# Altium schematic sheet 自定义键盘.SchDoc
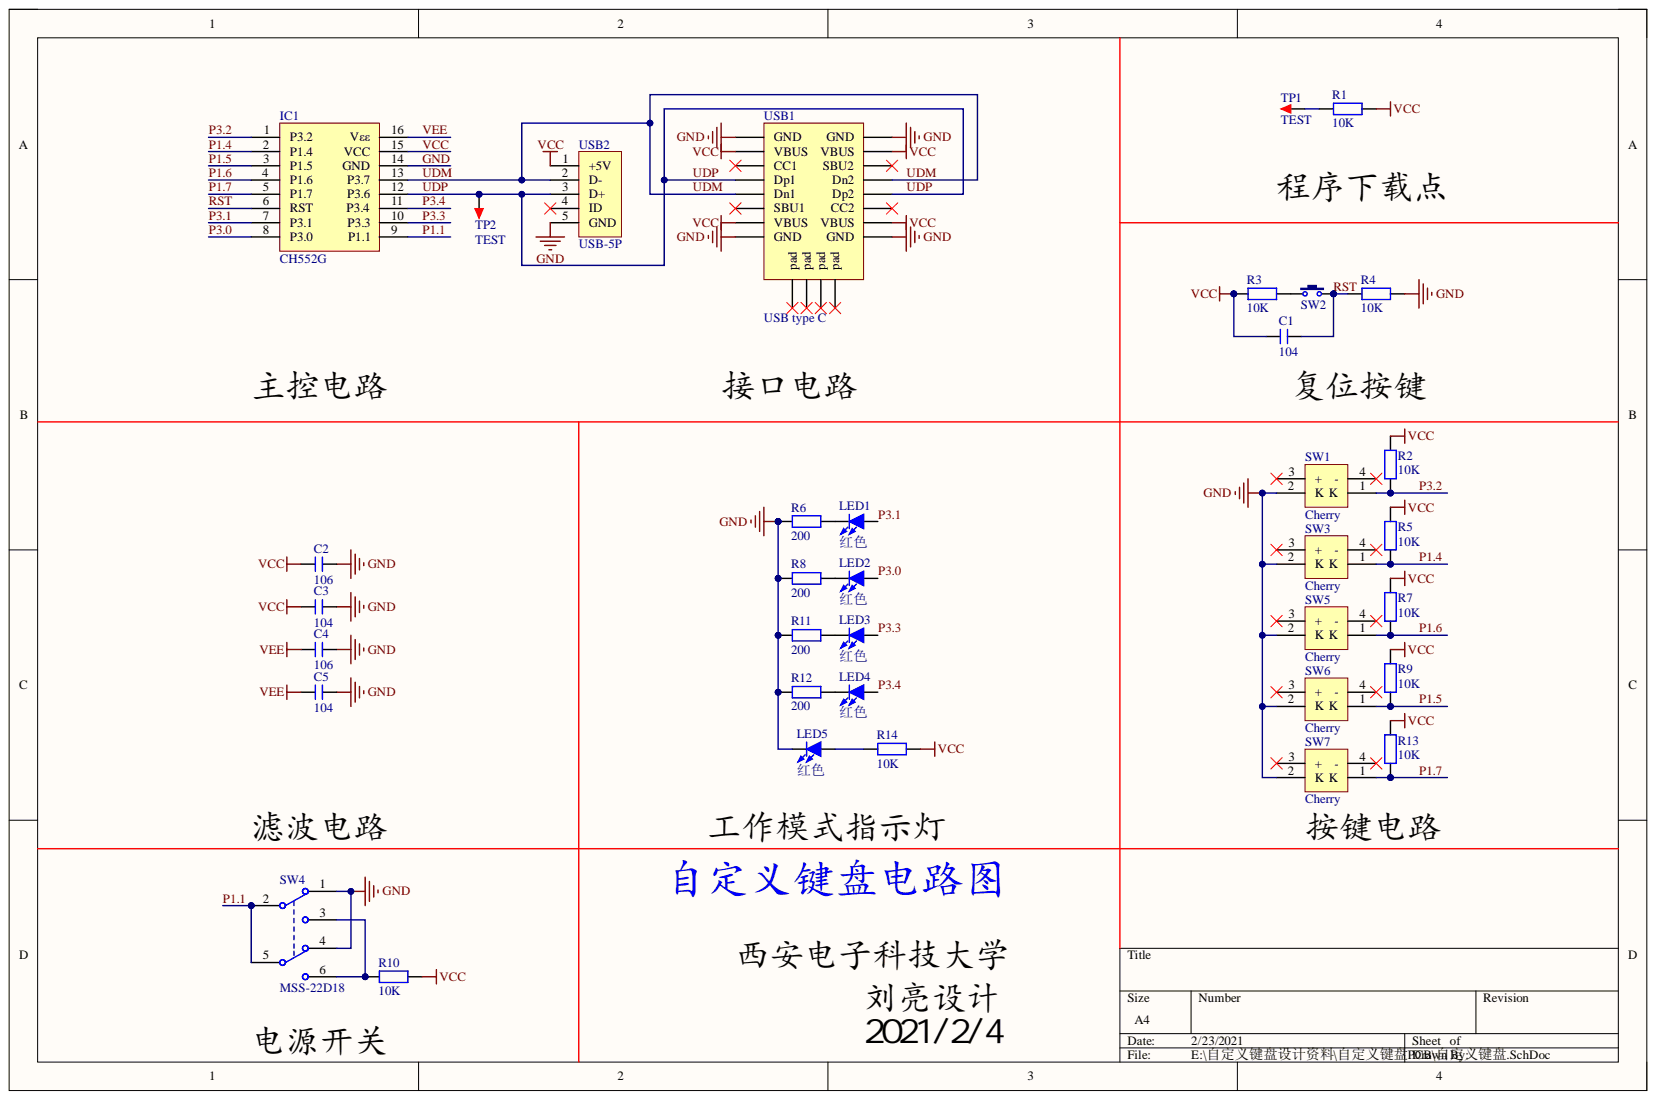
[diagram p0: 自定义键盘.SchDoc]

## Parts
C1  104  [C 0603_M]
C2  106  [C 0603_M]
C3  104  [C 0603_M]
C4  106  [C 0603_M]
C5  104  [C 0603_M]
IC1  CH552G  [SOP16_N]
LED1  红色  [LED 0603R]
LED2  红色  [LED 0603R]
LED3  红色  [LED 0603R]
LED4  红色  [LED 0603R]
LED5  红色  [LED 0603R]
R1  10K  [R 0603_M]
R2  10K  [R 0603_M]
R3  10K  [R 0603_M]
R4  10K  [R 0603_M]
R5  10K  [R 0603_M]
R6  200  [R 0603_M]
R7  10K  [R 0603_M]
R8  200  [R 0603_M]
R9  10K  [R 0603_M]
R10  10K  [R 0603_M]
R11  200  [R 0603_M]
R12  200  [R 0603_M]
R13  10K  [R 0603_M]
R14  10K  [R 0603_M]
SW1  Cherry  [LSW DIP-CHERRY_1]
SW2  3x6x5  [TSW SMD-3*6*2.5]  (value not drawn)
SW3  Cherry  [LSW DIP-CHERRY_1]
SW4  MSS-22D18  [MSS-22D18]
SW5  Cherry  [LSW DIP-CHERRY_1]
SW6  Cherry  [LSW DIP-CHERRY_1]
SW7  Cherry  [LSW DIP-CHERRY_1]
TP1  TEST  [TEST 1.2]
TP2  TEST  [TEST 1.2]
USB1  USB type C  [USB_C_16]
USB2  USB-5P  [USB-MICRO_D]

## Nets
GND: C2.1 C3.1 C4.1 C5.1 IC1.14(GND) LED5.2 R4.2 R6.1 R8.1 R11.1 R12.1 SW1.2(K) SW3.2(K) SW4.1 SW4.4 SW5.2(K) SW6.2(K) SW7.2(K) USB1.A1(GND) USB1.A12(GND) USB1.B1(GND) USB1.B12(GND) USB2.5(GND)
P1.1: IC1.9(P1.1) SW4.2 SW4.5
P1.4: IC1.2(P1.4) R5.2 SW3.1(K)
P1.5: IC1.3(P1.5) R9.2 SW6.1(K)
P1.6: IC1.4(P1.6) R7.2 SW5.1(K)
P1.7: IC1.5(P1.7) R13.2 SW7.1(K)
P3.0: IC1.8(P3.0) LED2.1
P3.1: IC1.7(P3.1) LED1.1
P3.2: IC1.1(P3.2) R2.2 SW1.1(K)
P3.3: IC1.10(P3.3) LED3.1
P3.4: IC1.11(P3.4) LED4.1
RST: C1.2 IC1.6(RST) R4.1 SW2.2
UDM: IC1.13(P3.7) USB1.A7(Dn1) USB1.B7(Dn2) USB2.2(D-)
UDP: IC1.12(P3.6) TP2.1 USB1.A6(Dp1) USB1.B6(Dp2) USB2.3(D+)
VCC: C1.1 C2.2 C3.2 IC1.15(VCC) R1.2 R2.1 R3.1 R5.1 R7.1 R9.1 R10.1 R13.1 R14.2 USB1.A4(VBUS) USB1.A9(VBUS) USB1.B4(VBUS) USB1.B9(VBUS) USB2.1(+5V)
VEE: C4.2 C5.2 IC1.16(Vεε)
NetLED1_2: LED1.2 R6.2
NetLED2_2: LED2.2 R8.2
NetLED3_2: LED3.2 R11.2
NetLED4_2: LED4.2 R12.2
NetLED5_1: LED5.1 R14.1
NetR1_1: R1.1 TP1.1
NetR3_2: R3.2 SW2.1
NetR10_2: R10.2 SW4.3 SW4.6

Not connected: SW1 3(+) 4(-); SW3 3(+) 4(-); SW5 3(+) 4(-); SW6 3(+) 4(-); SW7 3(+) 4(-); USB1 0(pad) A5(CC1) A8(SBU1) B5(CC2) B8(SBU2); USB2 4(ID)

## Library data of the parts
C1-C5 = C: 无极性贴片电容; 版权归属: 源创客; BOM名称: 无极性贴片电容; Component Kind: Standard; Library Name: 3.1   - 电阻-电容-电感.SchLib; 学习资源: www.ooocke.com
IC1 = CH552G: USB单片机芯片; Component Kind: Standard; Library Name: 1.1   - IC芯片.SchLib
LED1-LED5 = LED-SMD: 贴片LED; 版权归属: 源创客; BOM名称: 贴片LED; Component Kind: Standard; Library Name: 9.1   - LED.SchLib; 学习资源: www.ooocke.com
R1-R14 = R: 贴片电阻; 版权归属: 源创客; BOM名称: 贴片电阻; Component Kind: Standard; Library Name: 3.1   - 电阻-电容-电感.SchLib; 学习资源: www.ooocke.com
SW1 SW3 SW5-SW7 = LSW: 带灯机械按键开关; 版权归属: 源创客; BOM名称: 带灯机械按键开关; Component Kind: Standard; Library Name: 5.1   - 按键-开关.SchLib; 学习资源: www.ooocke.com
SW2 = TSW 3x6: 3x6轻触开关; 版权归属: 源创客; BOM名称: 3x6轻触开关; Component Kind: Standard; Library Name: 5.1   - 按键-开关.SchLib; 学习资源: www.ooocke.com
SW4 = MSS-22D18: 双边直插小开关; Component Kind: Standard; Library Name: 5.1   - 按键-开关.SchLib
TP1 TP2 = TEST: 测试点; 版权归属: 源创客; BOM名称: 测试点; Component Kind: Standard; Library Name: 15.1 - 未分类器件.SchLib; 学习资源: www.ooocke.com
USB1 = USB_C_16: Component Kind: Standard; Library Name: 4.1   - 接口类.SchLib
USB2 = USB-5P: 微型USB母座; 版权归属: 源创客; BOM名称: 微型USB母座; Component Kind: Standard; Library Name: 4.1   - 接口类.SchLib; 学习资源: www.ooocke.com
